# Altium schematic sheet Ethernet.SchDoc(Ethernet)
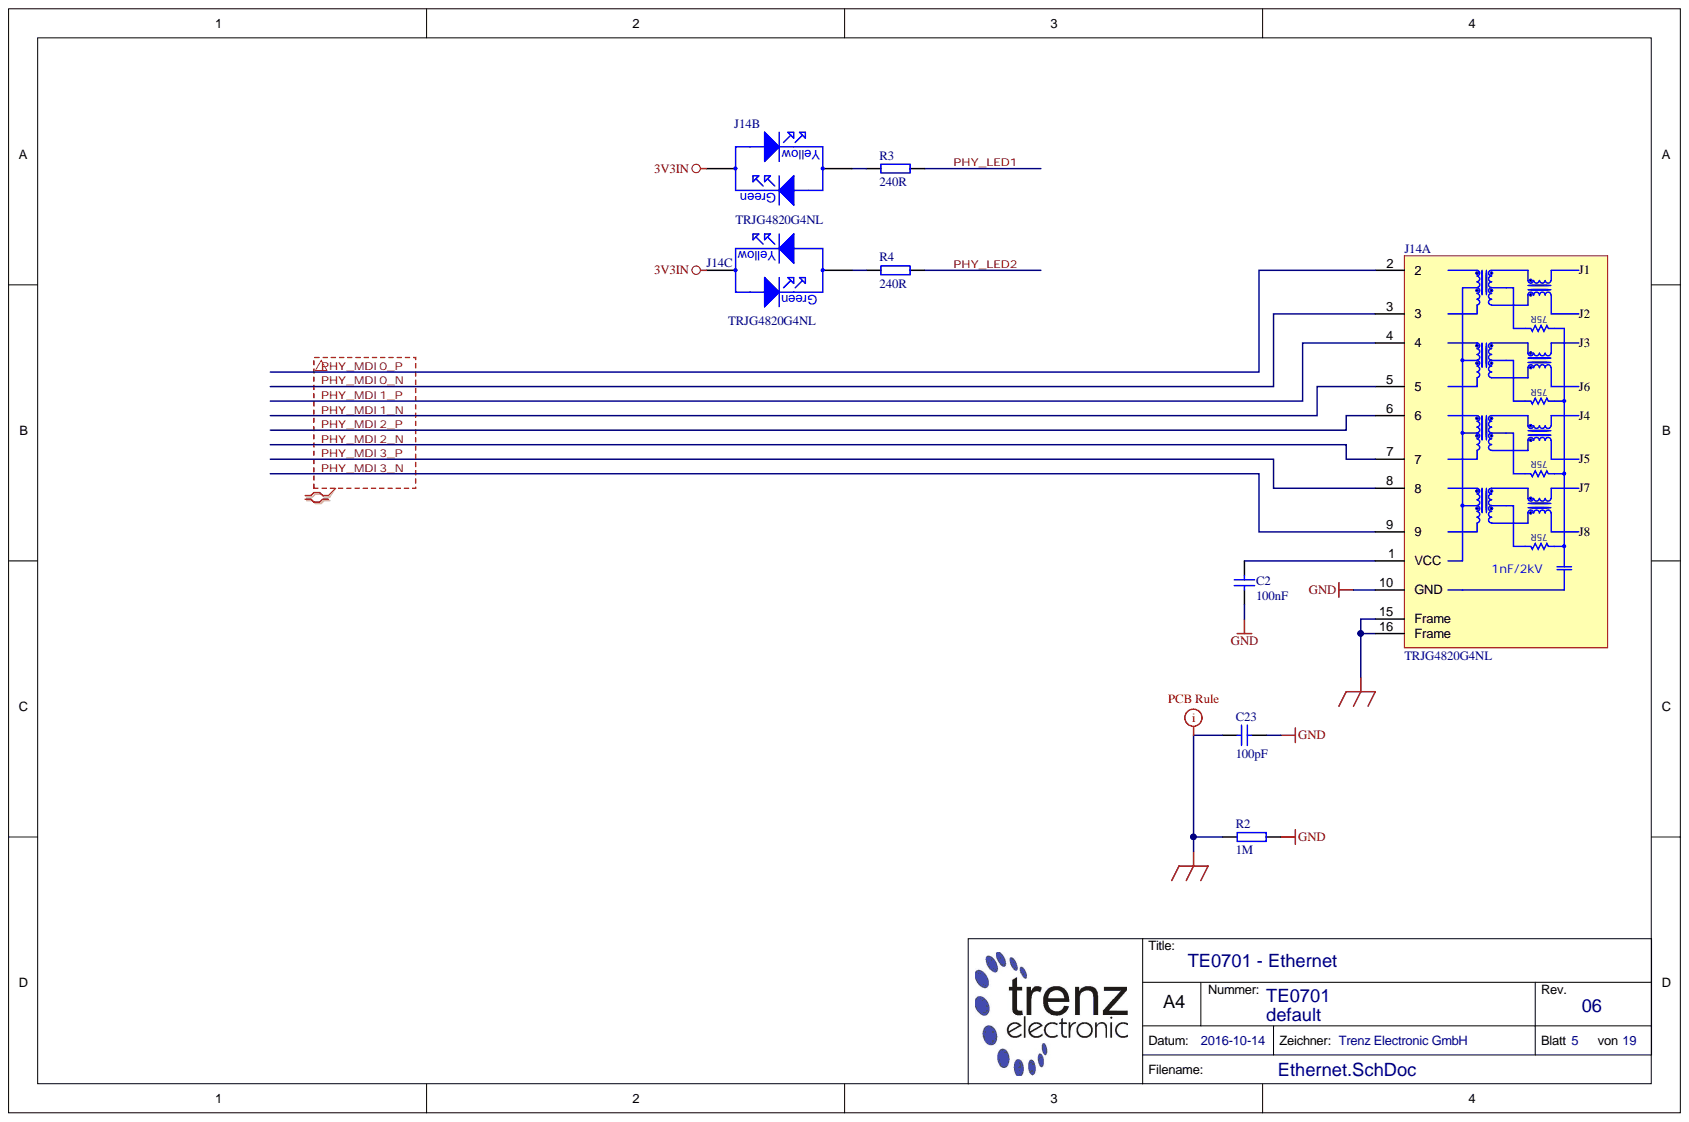
[diagram p0: Ethernet.SchDoc]

## Parts
C2  100nF  [CAPC1005X55N]
C23  100pF  [CAPC3216X180N]
J14  TRJG4820G4NL  [MIC38013-5151T-LF3]  (parts A,B,C)
R2  1M  [RESC3216X65N]
R3  240R  [RESC1608X55N]
R4  240R  [RESC1608X55N]

## Nets
3V3IN: J14.12 J14.13
FGND: C23.1 J14.15(Frame) J14.16(Frame) R2.1
GND: C2.1 C23.2 J14.10(GND) R2.2
PHY_LED1: R3.2
PHY_LED2: R4.2
PHY_MDI0_N: J14.3
PHY_MDI0_P: J14.2
PHY_MDI1_N: J14.5
PHY_MDI1_P: J14.4
PHY_MDI2_N: J14.7
PHY_MDI2_P: J14.6
PHY_MDI3_N: J14.9
PHY_MDI3_P: J14.8
NetC2_2: C2.2 J14.1(VCC)
NetJ14_11: J14.11 R3.1
NetJ14_14: J14.14 R4.1
N00142:

## Library data of the parts
C2 = 22472: KON 100nF 25V X5R SMD; Database Table Name: C_1005_0402; Mixed: SMT; Preferred: Yes; Value: 100n; AN: 22472; Case: 1005 (0402); Component Kind: Standard; Library Name: TE.DbLib; Technology: X7R; Voltage: 25V
C23 = 22679: KON 100pF 1000V X7R 10%; Database Table Name: C_3216_1206; Mixed: SMT; Preferred: Yes; Value: 100p; AN: 22679; Case: 3216 (1206); Component Kind: Standard; Library Name: TE.DbLib; Technology: 1000V; Tolerance: 10%; Voltage: X7R
J14 = 27357: LAN-Transformer 10/100/1000 BaseT; Database Table Name: CON_IO; Mixed: THT; Trenz-Stock: Yes; AN: 27357; Component Kind: Standard; H-ART: TRJG4820G4NL; Library Name: TE.DbLib; Technology: -40 to +85 °C; HelpURL: B:\ad\adlib\datasheet\TRJG4820G4NL
R2 = 22757: WID SMD 1M 5% 0,25W; Database Table Name: R_3216_1206; Mixed: SMT; Preferred: Yes; AN: 22757; Case: 3216 (1206); Component Kind: Standard; Library Name: TE.DbLib; Technology: TK100; Tolerance: 5%; Value: 1M
R3 R4 = 23598: WID SMD 240R 1% 0,1W; Database Table Name: R_1608_0603; Mixed: SMT; Preferred: Yes; Value: 240; AN: 23598; Case: 1608 (0603); Component Kind: Standard; Library Name: TE.DbLib; Technology: TK100; Tolerance: 1%
